# Altium schematic sheet Peripherals.SchDoc(Peripherals)
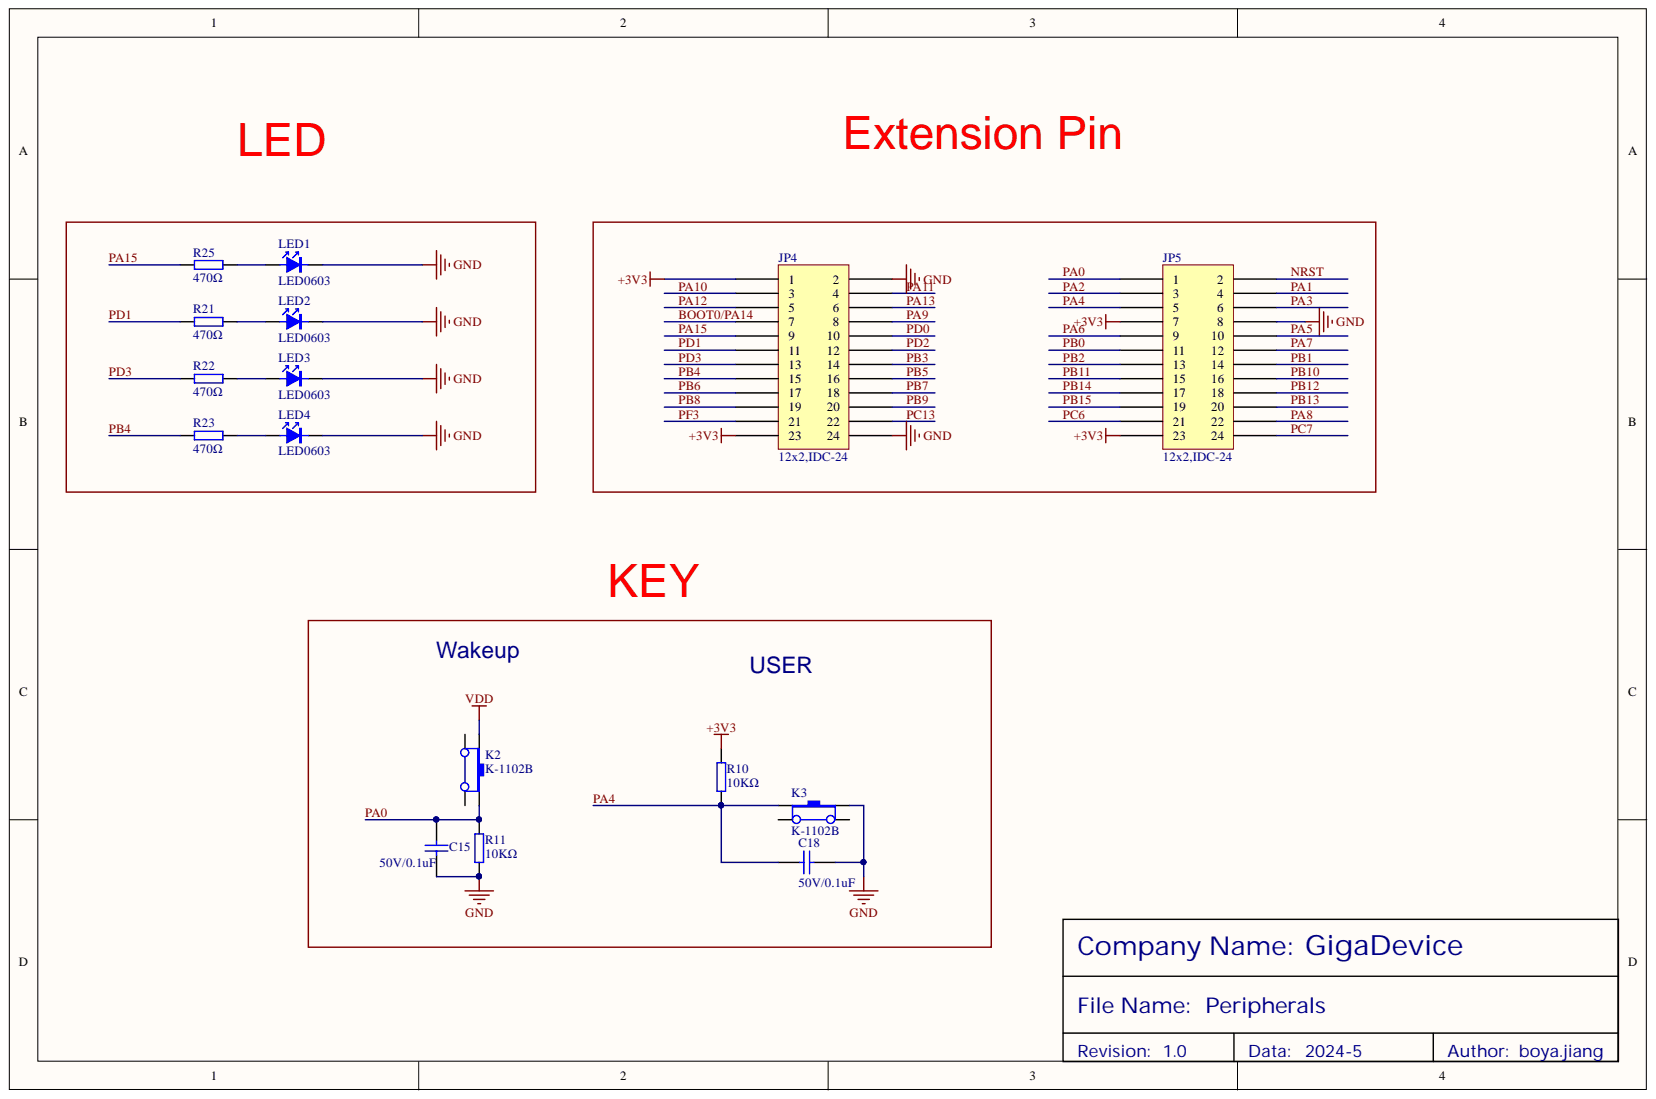
[diagram p0: Peripherals.SchDoc]

## Parts
C15  50V/0.1uF  [0603-C]
C18  50V/0.1uF  [0603-C]
JP4  12x2,IDC-24  [IDC-24]
JP5  12x2,IDC-24  [IDC-24]
K2  K-1102B  [K-1102B]
K3  K-1102B  [K-1102B]
LED1  LED0603  [0603-LED-Green]
LED2  LED0603  [0603-LED-Green]
LED3  LED0603  [0603-LED-Green]
LED4  LED0603  [0603-LED-Green]
R10  10KΩ  [0603-R]
R11  10KΩ  [0603-R]
R21  470Ω  [0603-R]
R22  470Ω  [0603-R]
R23  470Ω  [0603-R]
R25  470Ω  [0603-R]

## Nets
+3V3: JP4.1 JP4.23 JP5.7 JP5.23 R10.1
GND: C15.1 C18.2 JP4.2 JP4.24 JP5.8 K3.1 LED1.K LED2.K LED3.K LED4.K R11.2
VDD: K2.3
BOOT0/PA14: JP4.7
NRST: JP5.2
PA0: C15.2 JP5.1 K2.1 R11.1
PA1: JP5.4
PA2: JP5.3
PA3: JP5.6
PA4: C18.1 JP5.5 K3.3 R10.2
PA5: JP5.10
PA6: JP5.9
PA7: JP5.12
PA8: JP5.22
PA9: JP4.8
PA10: JP4.3
PA11: JP4.4
PA12: JP4.5
PA13: JP4.6
PA15: JP4.9 R25.1
PB0: JP5.11
PB1: JP5.14
PB2: JP5.13
PB3: JP4.14
PB4: JP4.15 R23.1
PB5: JP4.16
PB6: JP4.17
PB7: JP4.18
PB8: JP4.19
PB9: JP4.20
PB10: JP5.16
PB11: JP5.15
PB12: JP5.18
PB13: JP5.20
PB14: JP5.17
PB15: JP5.19
PC6: JP5.21
PC7: JP5.24
PC13: JP4.22
PD0: JP4.10
PD1: JP4.11 R21.1
PD2: JP4.12
PD3: JP4.13 R22.1
PF3: JP4.21
NetLED1_A: LED1.A R25.2
NetLED2_A: LED2.A R21.2
NetLED3_A: LED3.A R22.2
NetLED4_A: LED4.A R23.2

Not connected: K2 2 4; K3 2 4

## Library data of the parts
C15 C18 = 50V/0.1uF: 表贴电容; Component Kind: Standard; Library Name: KLTC-电容.SchLib
JP4 JP5 = HEADER 12X2: 双排插针; Component Kind: Standard; Library Name: boyajiang.SchLib
K2 K3 = K-1102B: 普通6X6X7直插按键; Component Kind: Standard; Library Name: KLTC-按键及接插件.SchLib
LED1-LED4 = LED0603: 表贴LED发光管; Component Kind: Standard; Library Name: xufei.SchLib
R10 R11 = 10KΩ: 表贴电阻; Component Kind: Standard; Library Name: KLTC-电阻.SchLib
R21-R23 R25 = 470Ω: 表贴电阻; Component Kind: Standard; Library Name: KLTC-电阻.SchLib
